# Altium schematic sheet OUTPUTS.SchDoc(OUTPUTS)
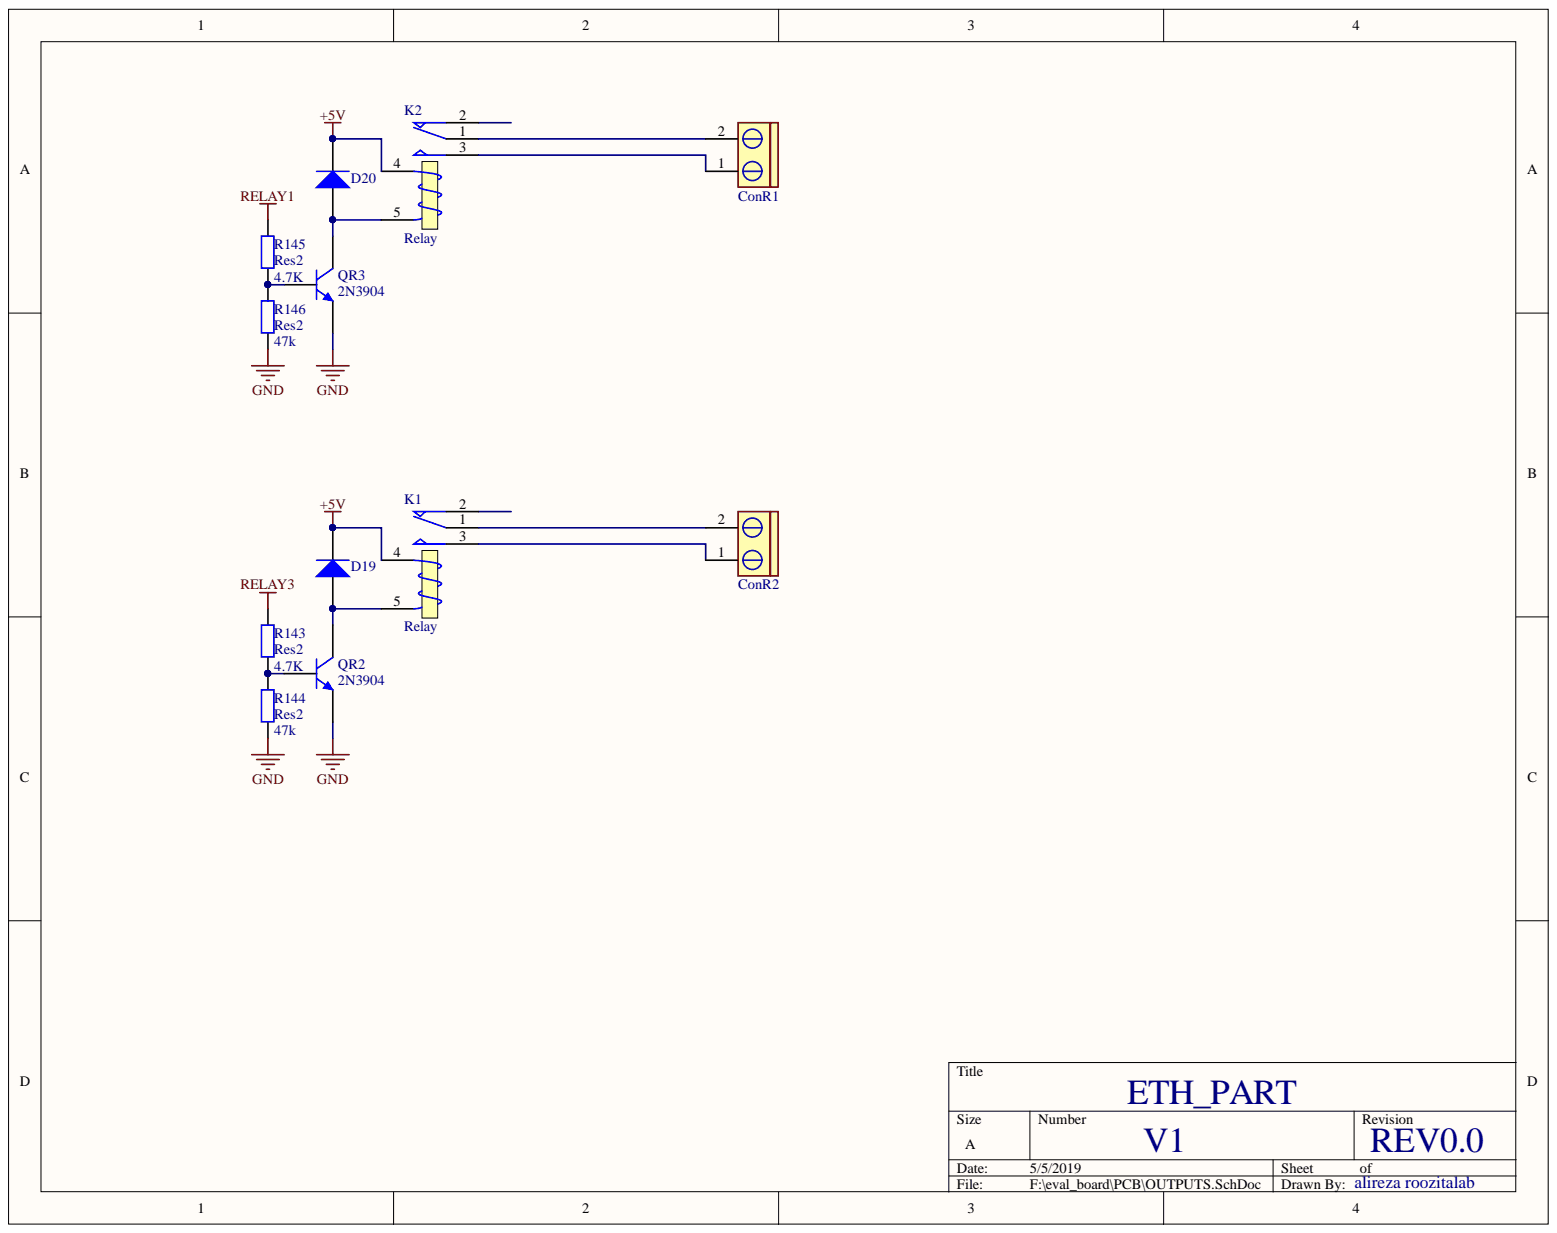
[diagram p0: OUTPUTS.SchDoc]

## Parts
ConR1  CON2 pin blue  [My_CN2]  (value not drawn)
ConR2  CON2 pin blue  [My_CN2]  (value not drawn)
D19  Diode 1N4148  [Diode-1n4148 - SMD PAD]  (value not drawn)
D20  Diode 1N4148  [Diode-1n4148 - SMD PAD]  (value not drawn)
K1  Relay  [Relay 18.85x15.35x15.70]
K2  Relay  [Relay 18.85x15.35x15.70]
QR2  2N3904  [2N3906_SMD]
QR3  2N3904  [2N3906_SMD]
R143  Res2  value 4.7K  [RES 1206 (3216X08L)]
R144  Res2  value 47k  [RES 1206 (3216X08L)]
R145  Res2  value 4.7K  [RES 1206 (3216X08L)]
R146  Res2  value 47k  [RES 1206 (3216X08L)]

## Nets
+5V: D19.2 D20.2 K1.4 K2.4
GND: QR2.1 QR3.1 R144.1 R146.1
RELAY1: R145.2
RELAY3: R143.2
NetConR1_1: ConR1.1 K2.3
NetConR1_2: ConR1.2 K2.1
NetConR2_1: ConR2.1 K1.3
NetConR2_2: ConR2.2 K1.1
NetD19_1: D19.1 K1.5 QR2.3
NetD20_1: D20.1 K2.5 QR3.3
NetQR2_2: QR2.2 R143.1 R144.2
NetQR3_2: QR3.2 R145.1 R146.2

Not connected: K1 2; K2 2

## Library data of the parts
ConR1 ConR2 = CON2 pin blue: Connector blue screw; Library Name: Mylib.IntLib; Component Kind: Standard
D19 D20 = Diode 1N4148: High Conductance Fast Diode; Simulation: 1N4148; Signal Integrity: 1N4148; Published: 8-Jun-2000; PackageReference: DO-35; Library Name: Miscellaneous Devices.IntLib; LatestRevisionNote: Re-released for DXP Platform.; LatestRevisionDate: 17-Jul-2002; DatasheetDocument: 1997; ComponentLink2URL: http://www.fairchildsemi.com/ds/1N%2F1N4148.pdf; ComponentLink2Description: Datasheet; ComponentLink1URL: http://www.fairchildsemi.com/; ComponentLink1Description: Manufacturer Link; Component Kind: Standard; Code_JEDEC: DO-204-AH; Publisher: Altium Limited
K1 K2 = Relay: SPDT Relay; Simulation: SPDTRELAY; Published: 8-Jun-2000; PackageReference: MODULE5B; Library Name: Miscellaneous Devices.IntLib; LatestRevisionNote: Re-released for DXP Platform.; LatestRevisionDate: 17-Jul-2002; Component Kind: Standard; Publisher: Altium Limited
QR2 QR3 = 2N3904: NPN General Purpose Amplifier; Simulation: 2N3904; Signal Integrity: 2N3904; Published: 8-Jun-2000; PackageReference: TO-92A; PackageDocument: Sep-1998; Library Name: Miscellaneous Devices.IntLib; LatestRevisionNote: PCB Footprint 'BCY-W3/D4.7' replaced; LatestRevisionDate: 15-Jan-2003; DatasheetDocument: 1997; ComponentLink2URL: http://www.fairchildsemi.com/ds/2N%2F2N3904.pdf; ComponentLink2Description: Datasheet; ComponentLink1URL: http://www.fairchildsemi.com/; ComponentLink1Description: Manufacturer Link; Component Kind: Standard; Code_JEDEC: TO-92A; Publisher: Altium Limited
R143-R146 = Res2: Resistor; Simulation: RESISTOR; Signal Integrity: Res; Published: 8-Jun-2000; PackageReference: AXIAL-0.4; Library Name: Miscellaneous Devices.IntLib; LatestRevisionNote: Re-released for DXP Platform.; LatestRevisionDate: 17-Jul-2002; Component Kind: Standard; Publisher: Altium Limited
